# Altium schematic sheet Jetbot_controler.SchDoc
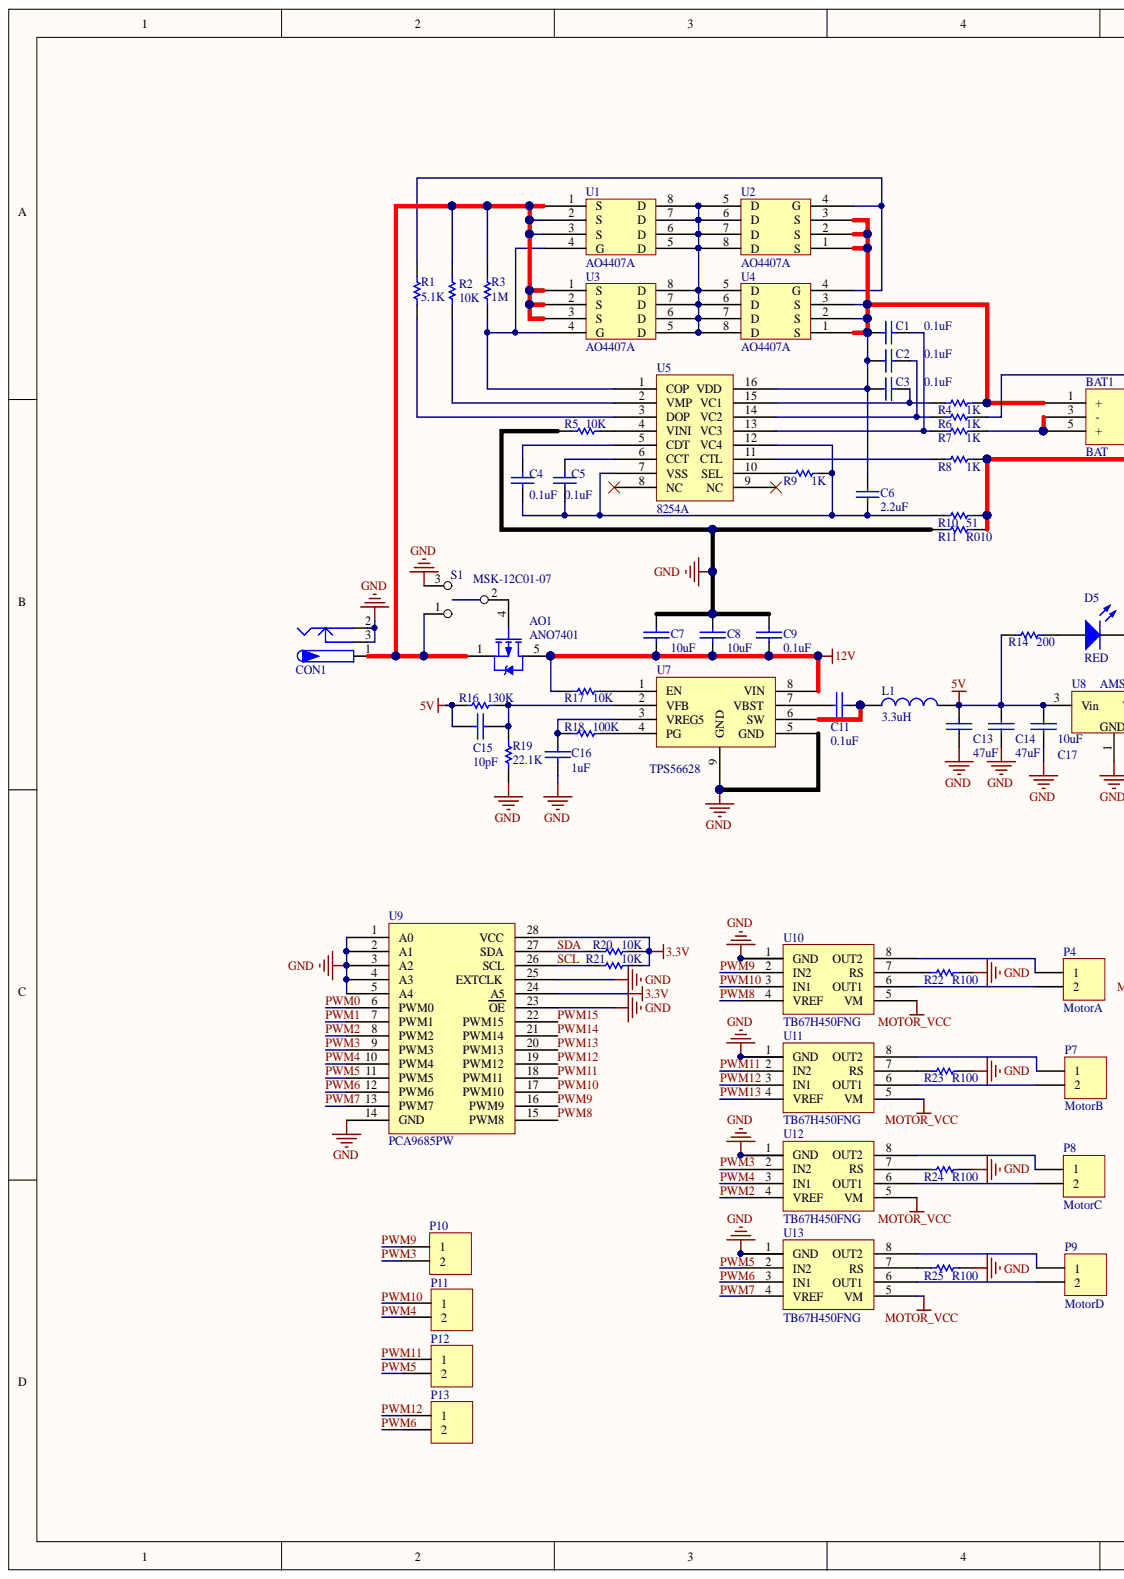
[diagram p0: Jetbot_controler.SchDoc, left]
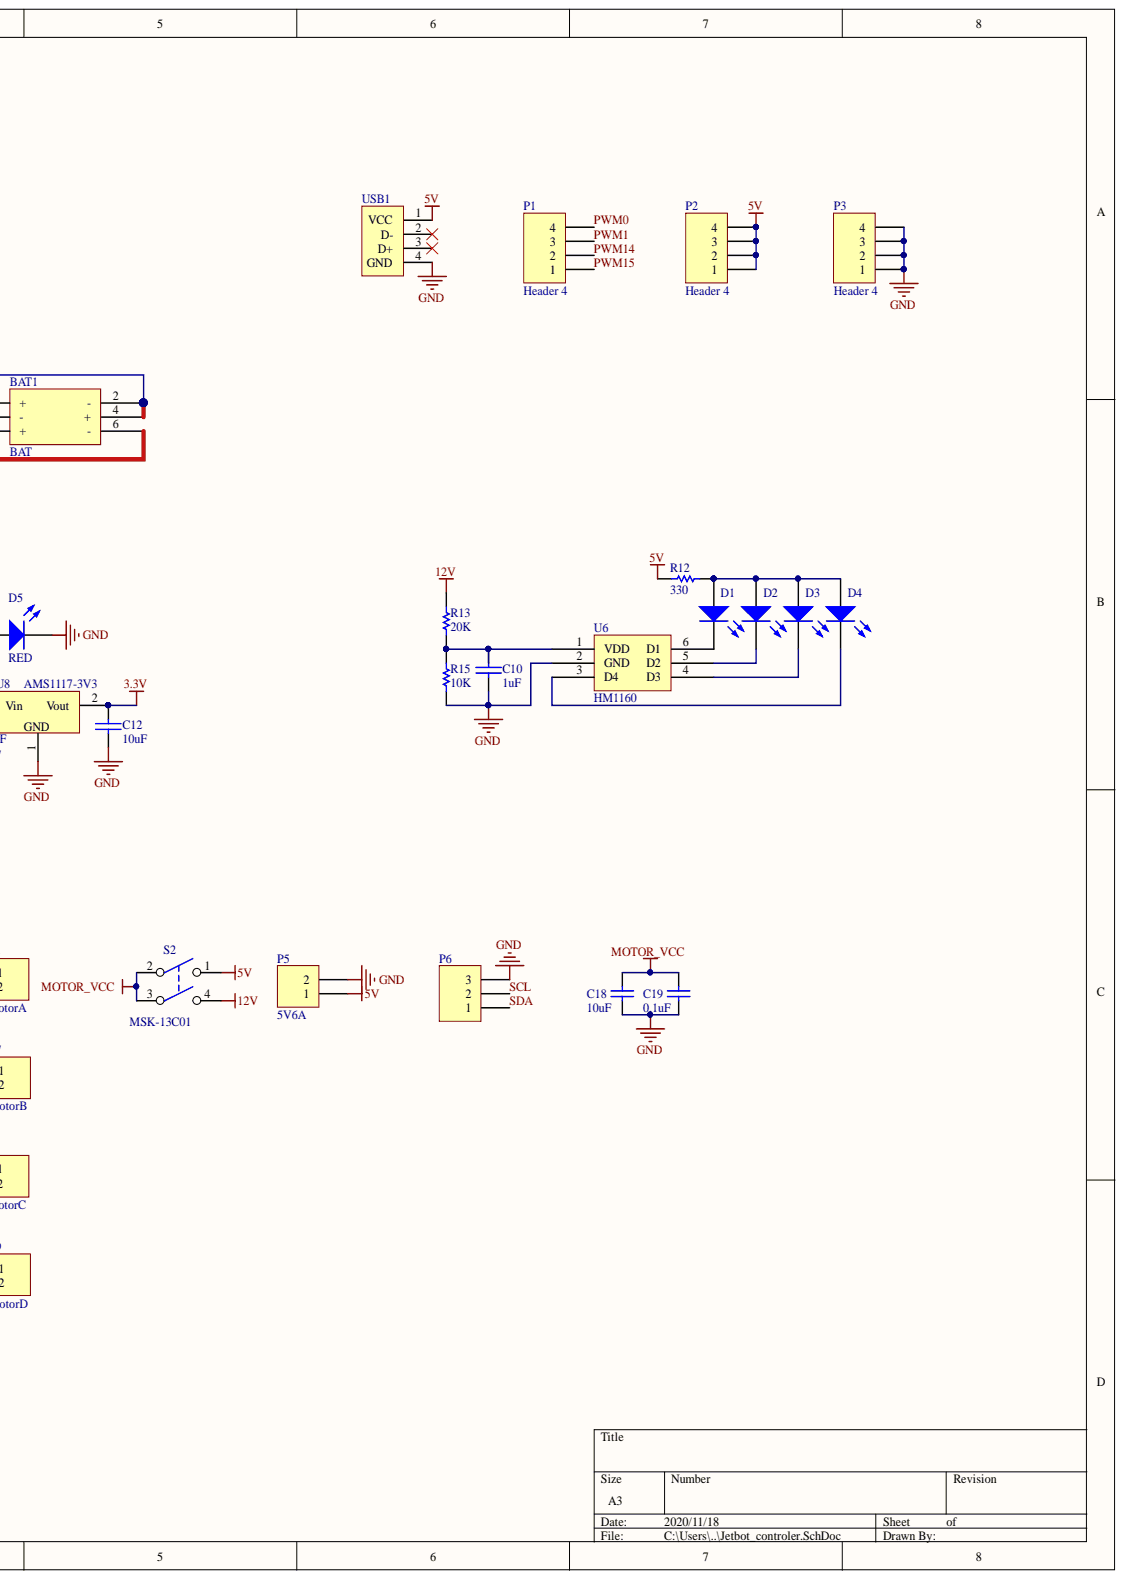
[diagram p1: Jetbot_controler.SchDoc, right]

## Parts
AO1  ANO7401  [DFN3*3]
BAT1  BAT  [18650-3]
C1  0.1uF  [0805-0C]
C2  0.1uF  [0805-0C]
C3  0.1uF  [0805-0C]
C4  0.1uF  [0805-0C]
C5  0.1uF  [0805-0C]
C6  2.2uF  [0805-0C]
C7  10uF  [0805-0C]
C8  10uF  [0805-0C]
C9  0.1uF  [0805-0C]
C10  1uF  [0805-0C]
C11  0.1uF  [0805-0C]
C12  10uF  [0805-0C]
C13  47uF  [1206C]
C14  47uF  [1206C]
C15  10pF  [0805-0C]
C16  1uF  [0805-0C]
C17  10uF  [0805-0C]
C18  10uF  [0805-0C]
C19  0.1uF  [0805-0C]
CON1  5.5*2.1  [DC-005]  (value not drawn)
D1  GREEN  [LED0805G]  (value not drawn)
D2  GREEN  [LED0805G]  (value not drawn)
D3  GREEN  [LED0805G]  (value not drawn)
D4  GREEN  [LED0805G]  (value not drawn)
D5  RED  [LED0805R]
L1  3.3uH  [L-SMD-0630]
P1  Header 4  [HDR-1X4]
P2  Header 4  [HDR-1X4]
P3  Header 4  [HDR-1X4]
P4  MotorA  [XH2.54-2P]
P5  5V6A  [CDS-508H-2P]
P6  (no value)  [XH2.54-3P_H]
P7  MotorB  [XH2.54-2P]
P8  MotorC  [XH2.54-2P]
P9  MotorD  [XH2.54-2P]
P10  (no value)  [JUMP]
P11  (no value)  [JUMP]
P12  (no value)  [JUMP]
P13  (no value)  [JUMP]
R1  5.1K  [0805-0R]
R2  10K  [0805-0R]
R3  1M  [0805-0R]
R4  1K  [0805-0R]
R5  10K  [0805-0R]
R6  1K  [0805-0R]
R7  1K  [0805-0R]
R8  1K  [0805-0R]
R9  1K  [0805-0R]
R10  51  [0805-0R]
R11  R010  [2512-R]
R12  330  [0805-0R]
R13  20K  [0805-0R]
R14  200  [0805-0R]
R15  10K  [0805-0R]
R16  130K  [0805-0R]
R17  10K  [0805-0R]
R18  100K  [0805-0R]
R19  22.1K  [0805-0R]
R20  10K  [0805-0R]
R21  10K  [0805-0R]
R22  R100  [0805-0R]
R23  R100  [0805-0R]
R24  R100  [0805-0R]
R25  R100  [0805-0R]
S1  MSK-12C01-07  [MSK-12C01-07]
S2  MSK-13C01  [MSK-13C01]
U1  AO4407A  [SO-8]
U2  AO4407A  [SO-8]
U3  AO4407A  [SO-8]
U4  AO4407A  [SO-8]
U5  8254A  [TSSOP-16]
U6  HM1160  [SOT23-6L]
U7  TPS56628  [SOp8-9]
U8  AMS1117-3V3  [SOT-223 - 3]
U9  PCA9685PW  [TSSOP28]
U10  TB67H450FNG  [SOP8-9]
U11  TB67H450FNG  [SOP8-9]
U12  TB67H450FNG  [SOP8-9]
U13  TB67H450FNG  [SOP8-9]
USB1  USB接口  [USB-A]  (value not drawn)

## Nets
3.3V: C12.2 R20.2 R21.1 U8.2 U9.24(A5) U9.28(VCC)
5V: C13.2 C14.2 C15.1 C17.2 L1.2 P2.1 P2.2 P2.3 P2.4 P5.1 R12.1 R14.2 R16.2 S2.1 U8.3 USB1.1(VCC)
12V: AO1.5 C7.2 C8.2 C9.2 R13.2 R17.2 S2.4 U7.8(VIN)
GND: C7.1 C8.1 C9.1 C10.1 C12.1 C13.1 C14.1 C16.1 C17.1 C18.1 C19.1 CON1.2 CON1.3 D5.2 P3.1 P3.2 P3.3 P3.4 P5.2 P6.3 R5.2 R11.2 R15.1 R19.2 R22.1 R23.1 R24.1 R25.1 S1.3 U6.2(GND) U7.5(GND) U7.9(GND) U8.1 U9.1(A0) U9.2(A1) U9.3(A2) U9.4(A3) U9.5(A4) U9.14(GND) U9.23(OE) U9.25(EXTCLK) U10.1(GND) U10.9 U11.1(GND) U11.9 U12.1(GND) U12.9 U13.1(GND) U13.9 USB1.4(GND)
MOTOR_VCC: C18.2 C19.2 S2.2 S2.3 U10.5(VM) U11.5(VM) U12.5(VM) U13.5(VM)
PWM0: P1.4 U9.6(PWM0)
PWM1: P1.3 U9.7(PWM1)
PWM2: U9.8(PWM2) U12.4(VREF)
PWM3: P10.2 U9.9(PWM3) U12.2(IN2)
PWM4: P11.2 U9.10(PWM4) U12.3(IN1)
PWM5: P12.2 U9.11(PWM5) U13.2(IN2)
PWM6: P13.2 U9.12(PWM6) U13.3(IN1)
PWM7: U9.13(PWM7) U13.4(VREF)
PWM8: U9.15(PWM8) U10.4(VREF)
PWM9: P10.1 U9.16(PWM9) U10.2(IN2)
PWM10: P11.1 U9.17(PWM10) U10.3(IN1)
PWM11: P12.1 U9.18(PWM11) U11.2(IN2)
PWM12: P13.1 U9.19(PWM12) U11.3(IN1)
PWM13: U9.20(PWM13) U11.4(VREF)
PWM14: P1.2 U9.21(PWM14)
PWM15: P1.1 U9.22(PWM15)
SCL: P6.2 R21.2 U9.26(SCL)
SDA: P6.1 R20.1 U9.27(SDA)
NetAO1_1: AO1.1 CON1.1 R2.2 R3.2 S1.1 U1.1(S) U1.2(S) U1.3(S) U3.1(S) U3.2(S) U3.3(S)
NetAO1_4: AO1.4 S1.2
NetBAT1_1: BAT1.1(+) C1.2 C2.2 C3.2 C6.2 R4.1 U2.1(S) U2.2(S) U2.3(S) U4.1(S) U4.2(S) U4.3(S) U5.16(VDD)
NetBAT1_2: BAT1.2(-) BAT1.4(+) R6.1
NetBAT1_3: BAT1.3(-) BAT1.5(+) R7.1
NetBAT1_6: BAT1.6(-) R8.1 R10.2 R11.1
NetC1_1: C1.1 R7.2 U5.13(VC3)
NetC2_1: C2.1 R6.2 U5.14(VC2)
NetC3_1: C3.1 R4.2 U5.15(VC1)
NetC4_1: C4.1 C5.1 C6.1 R9.1 R10.1 U5.7(VSS) U5.12(VC4)
NetC4_2: C4.2 U5.5(CDT)
NetC5_2: C5.2 U5.6(CCT)
NetC10_2: C10.2 R13.1 R15.2 U6.1(VDD)
NetC11_1: C11.1 U7.7(VBST)
NetC11_2: C11.2 L1.1 U7.6(SW)
NetC15_2: C15.2 R16.1 R19.1 U7.2(VFB)
NetC16_2: C16.2 R18.2 U7.3(VREG5)
NetD1_1: D1.1 D2.1 D3.1 D4.1 R12.2
NetD1_2: D1.2 U6.6(D1)
NetD2_2: D2.2 U6.5(D2)
NetD3_2: D3.2 U6.4(D3)
NetD4_2: D4.2 U6.3(D4)
NetD5_1: D5.1 R14.1
NetP4_1: P4.1 U10.8(OUT2)
NetP4_2: P4.2 U10.6(OUT1)
NetP7_1: P7.1 U11.8(OUT2)
NetP7_2: P7.2 U11.6(OUT1)
NetP8_1: P8.1 U12.8(OUT2)
NetP8_2: P8.2 U12.6(OUT1)
NetP9_1: P9.1 U13.8(OUT2)
NetP9_2: P9.2 U13.6(OUT1)
NetR1_1: R1.1 U5.3(DOP)
NetR1_2: R1.2 U2.4(G) U4.4(G)
NetR2_1: R2.1 U5.2(VMP)
NetR3_1: R3.1 U1.4(G) U3.4(G) U5.1(COP)
NetR5_1: R5.1 U5.4(VINI)
NetR8_2: R8.2 U5.11(CTL)
NetR9_2: R9.2 U5.10(SEL)
NetR17_1: R17.1 U7.1(EN)
NetR18_1: R18.1 U7.4(PG)
NetR22_2: R22.2 U10.7(RS)
NetR23_2: R23.2 U11.7(RS)
NetR24_2: R24.2 U12.7(RS)
NetR25_2: R25.2 U13.7(RS)
NetU1_5: U1.5(D) U1.6(D) U1.7(D) U1.8(D) U2.5(D) U2.6(D) U2.7(D) U2.8(D) U3.5(D) U3.6(D) U3.7(D) U3.8(D) U4.5(D) U4.6(D) U4.7(D) U4.8(D)

Not connected: U5 8(NC) 9(NC); USB1 2(D-) 3(D+)

## Library data of the parts
AO1 = P-MOS: MOS管; Component Kind: Standard; Library Name: Timaker.SchLib
BAT1 = BAT_3: 电池盒; Component Kind: Standard; Library Name: Timaker.SchLib
C1-C6 C18 C19 = Cap: Capacitor; Component Kind: Standard; Library Name: Miscellaneous Devices.IntLib; Signal Integrity: Cap; Simulation: CAP
  C18: LatestRevisionDate: 17-Jul-2002; LatestRevisionNote: Re-released for DXP Platform.; PackageDescription: Radial Cap, Thru-Hole; 2 Leads; 0.3 in Pin Spacing; PackageReference: RAD-0.3; Published: 8-Jun-2000; Publisher: Altium Limited
C7-C17 = CAP: Capacitor; Component Kind: Standard; Library Name: Miscellaneous Devices.SCHLIB
CON1 = P-DC: 低压电源接口; BOM名称: DC电源接口; 版权归属: 源创客; Component Kind: Standard; Library Name: 接口类.SchLib; 学习资源: www.ooocke.com
D1-D5 = LED0: LED; Component Kind: Standard; Library Name: Miscellaneous Devices.IntLib; Simulation: LED0
L1 = L: 小功率贴片电感; 版权归属: 源创客; BOM名称: 小功率贴片电感; Component Kind: Standard; Library Name: 电阻-电容-电感.SchLib; 学习资源: www.ooocke.com
P1-P3 = Header 4: Header, 4-Pin; Component Kind: Standard; LatestRevisionDate: 17-Jul-2002; LatestRevisionNote: Re-released for DXP Platform.; Library Name: Miscellaneous Connectors.IntLib; Signal Integrity: Connector; Publisher: Altium Limited
P4 P8 = Header 2: XH2.54, 弯针; Component Kind: Standard; Library Name: Miscellaneous Connectors.IntLib; Signal Integrity: Connector
P5 = Header 2: KF2EDGK,弯针; Component Kind: Standard; Library Name: Miscellaneous Connectors.IntLib; Signal Integrity: Connector
P6 = Header 3: XH2.54, 弯针; Component Kind: Standard; Library Name: Miscellaneous Connectors.IntLib; Signal Integrity: Connector
P7 P9 = Header 2: XH2.54,弯针; Component Kind: Standard; Library Name: Miscellaneous Connectors.IntLib; Signal Integrity: Connector
P10-P13 = Header 2: Component Kind: Standard; Library Name: Miscellaneous Connectors.IntLib; Signal Integrity: Connector
R1-R25 = Res1: Resistor; Component Kind: Standard; Library Name: Miscellaneous Devices.IntLib; Signal Integrity: Res; Simulation: RESISTOR
S1 = SW-SPDT: 拨动开关; Component Kind: Standard; Library Name: 开关.SCHLIB
S2 = SW DPST: 拨码开关,1P3T; Component Kind: Standard; Library Name: 开关.SCHLIB
U1-U4 = AO4407A: MOS管; Component Kind: Standard; Library Name: Timaker.SchLib
U5 = S-8254A: 电源管理芯片; Component Kind: Standard; Library Name: Timaker.SchLib
U6 = HM1160: 电量指示; Component Kind: Standard; Library Name: Timaker.SchLib
U7 = TPS56628: 5V稳压芯片; Component Kind: Standard; Library Name: Timaker.SchLib
U8 = AMS1117: 三端稳压芯片; BOM名称: 稳压芯片; 版权归属: 源创客; Component Kind: Standard; Library Name: IC芯片.SchLib; 学习资源: www.ooocke.com
USB1 = USB-A/S: USB-A型母接口; Component Kind: Standard; Library Name: 接口类.SchLib
U9 = PCA9685PW: Component Kind: Standard; Library Name: Schlib1.SchLib
U10-U13 = TB67H450FNG: Component Kind: Standard; Library Name: Timaker.SchLib
